# Altium schematic sheet main.SchDoc
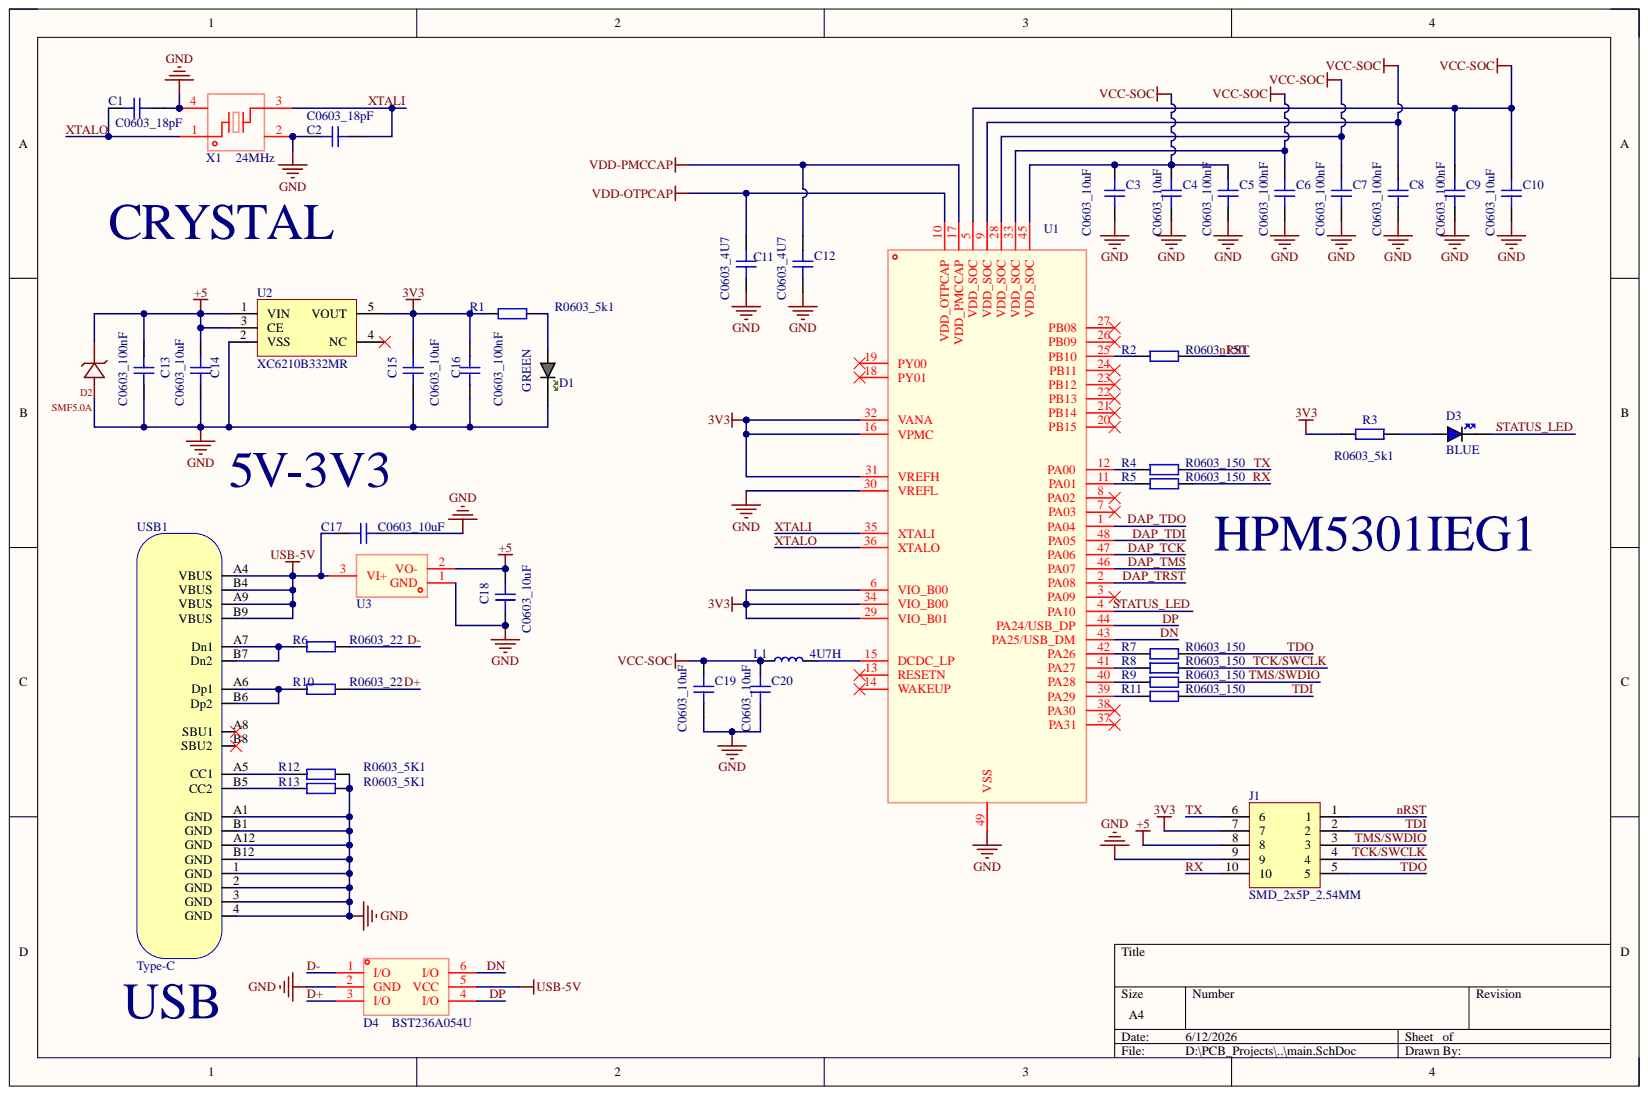
[diagram p0: main.SchDoc]

## Parts
C1  C0603_18pF  [CAP_0603]
C2  C0603_18pF  [CAP_0603]
C3  C0603_10uF  [CAP_0603]
C4  C0603_10uF  [CAP_0603]
C5  C0603_100nF  [CAP_0603]
C6  C0603_100nF  [CAP_0603]
C7  C0603_100nF  [CAP_0603]
C8  C0603_100nF  [CAP_0603]
C9  C0603_100nF  [CAP_0603]
C10  C0603_10uF  [CAP_0603]
C11  C0603_4U7  [CAP_0603]
C12  C0603_4U7  [CAP_0603]
C13  C0603_100nF  [CAP_0603]
C14  C0603_10uF  [CAP_0603]
C15  C0603_10uF  [CAP_0603]
C16  C0603_100nF  [CAP_0603]
C17  C0603_10uF  [CAP_0603]
C18  C0603_10uF  [CAP_0603]
C19  C0603_10uF  [CAP_0603]
C20  C0603_10uF  [CAP_0603]
D1  GREEN  [LED_0805_GREEN]
D2  SMF5.0A  [SOD-123FL_L2.7-W1.8-LS3.8-RD]
D3  BLUE  [LED_0805_BLUE]
D4  BST236A054U  [SOT-23-6_L2.9-W1.6-P0.95-LS2.8-BR]
J1  SMD_2x5P_2.54MM  [SMD_2x5P_2.54MM]
L1  4U7H  [IND_1008]
R1  R0603_5k1  [RES_0603]
R2  R0603_150  [RES_0603]
R3  R0603_5k1  [RES_0603]
R4  R0603_150  [RES_0603]
R5  R0603_150  [RES_0603]
R6  R0603_22  [RES_0603]
R7  R0603_150  [RES_0603]
R8  R0603_150  [RES_0603]
R9  R0603_150  [RES_0603]
R10  R0603_22  [RES_0603]
R11  R0603_150  [RES_0603]
R12  R0603_5K1  [RES_0603]
R13  R0603_5K1  [RES_0603]
U1  HPM5301IEG1  [QFN-48_L6.0-W6.0-P0.40-TL-EP4.2]
U2  XC6210B332MR  [SOT-23-5]
U3  CH213K  [SOT-23-3_L2.9-W1.6-P1.90-LS2.8-BR]  (value not drawn)
USB1  Type-C  [TYPE-C_16P]
X1  24MHz  [CRYSTAL-SMD_4P-L2.5-W2.0-BL-A]

## Nets
3V3: C15.2 C16.2 J1.7 R1.1 R3.1 U1.6(VIO_B00) U1.16(VPMC) U1.29(VIO_B01) U1.31(VREFH) U1.32(VANA) U1.34(VIO_B00) U2.5(VOUT)
+5: C13.1 C14.2 C18.2 D2.1 J1.8 U2.1(VIN) U2.3(CE) U3.2
GND: C1.1 C2.2 C3.1 C4.1 C5.1 C6.1 C7.1 C8.1 C9.1 C10.1 C11.1 C12.1 C13.2 C14.1 C15.1 C16.1 C17.1 C18.1 C19.1 C20.1 D1.K D2.2 D4.2(GND) J1.9 R12.2 R13.2 U1.30(VREFL) U1.49(VSS) U2.2(VSS) U3.1 USB1.1(GND) USB1.2(GND) USB1.3(GND) USB1.4(GND) USB1.A1(GND) USB1.A12(GND) USB1.B1(GND) USB1.B12(GND) X1.2 X1.4
USB-5V: C17.2 D4.5(VCC) U3.3 USB1.A4(VBUS) USB1.A9(VBUS) USB1.B4(VBUS) USB1.B9(VBUS)
VCC-SOC: C3.2 C4.2 C5.2 C6.2 C7.2 C8.2 C9.2 C10.2 C19.2 C20.2 L1.1 U1.5(VDD_SOC) U1.9(VDD_SOC) U1.28(VDD_SOC) U1.33(VDD_SOC) U1.45(VDD_SOC)
VDD-OTPCAP: C11.2 U1.10(VDD_OTPCAP)
VDD-PMCCAP: C12.2 U1.17(VDD_PMCCAP)
D+: D4.3(I/O) R10.2
D-: D4.1(I/O) R6.2
DAP_TCK: U1.47(PA06)
DAP_TDI: U1.48(PA05)
DAP_TDO: U1.1(PA04)
DAP_TMS: U1.46(PA07)
DAP_TRST: U1.2(PA08)
DN: D4.6(I/O) U1.43(PA25/USB_DM)
DP: D4.4(I/O) U1.44(PA24/USB_DP)
nRST: J1.1 R2.2
RX: J1.10 R5.2
STATUS_LED: D3.K U1.4(PA10)
TCK/SWCLK: J1.4 R8.2
TDI: J1.2 R11.2
TDO: J1.5 R7.2
TMS/SWDIO: J1.3 R9.2
TX: J1.6 R4.2
XTALI: C2.1 U1.35(XTALI) X1.3
XTALO: C1.2 U1.36(XTALO) X1.1
NetD1_A: D1.A R1.2
NetD3_A: D3.A R3.2
NetL1_2: L1.2 U1.15(DCDC_LP)
NetR2_1: R2.1 U1.25(PB10)
NetR4_1: R4.1 U1.12(PA00)
NetR5_1: R5.1 U1.11(PA01)
NetR6_1: R6.1 USB1.A7(Dn1) USB1.B7(Dn2)
NetR7_1: R7.1 U1.42(PA26)
NetR8_1: R8.1 U1.41(PA27)
NetR9_1: R9.1 U1.40(PA28)
NetR10_1: R10.1 USB1.A6(Dp1) USB1.B6(Dp2)
NetR11_1: R11.1 U1.39(PA29)
NetR12_1: R12.1 USB1.A5(CC1)
NetR13_1: R13.1 USB1.B5(CC2)

Not connected: U1 3(PA09) 7(PA03) 8(PA02) 13(RESETN) 14(WAKEUP) 18(PY01) 19(PY00) 20(PB15) 21(PB14) 22(PB13) 23(PB12) 24(PB11) 26(PB09) 27(PB08) 37(PA31) 38(PA30); U2 4(NC); USB1 A8(SBU1) B8(SBU2)

## Library data of the parts
C1-C20 = CAP_0603: Capacitor SMD 0603; Component Kind: Standard; Library Name: MyLib.SchLib
D1 = LED_0805_GREEN: LED 0805 GREEN SMD; Component Kind: Standard; Library Name: MyLib.SchLib
D2 = SMF5.0A_C19077497: 极性:单向;反向截止电压(Vrwm):5V;钳位电压:9.2V;峰值脉冲电流(Ipp):21.7A@10/1000us;峰值脉冲功率(Ppp):200W@10/1000us;击穿电压:7V;; Manufacturer: R+O(宏嘉诚); AddInBom: yes; Clamping Voltage: 9.2V; level of protection: IEC 61000-4-2; ModelId: 86f9151e155e4fe2af0c4f2e0a80302e聨0819f05c4eef4c71ace90d822a990e87; ModelName: SOD-123FL_L2.8-W1.8-H1.1-LS3.6; ModelTransform: 141.732,70.866,0,180,0,0,0,0,0; Number of Channels: 单路; Polarity: 单向; Reverse Leakage Current (Ir): 400uA; Reverse Stand-Off Voltage (Vrwm): 5V; Type: TVS; Voltage - Breakdown: 7V; Component Kind: Standard; ConvertToPcb: yes; Datasheet: https://item.szlcsc.com/datasheet/SMF5.0A/20275582.html; Device: SMF5.0A_C19077497; FootprintName: SOD-123FL_L2.7-W1.8-LS3.8-RD; JLCPCB Part Class: Extended Part; Junction Capacitance: -; LCSC Part Name: 单向TVS 5V截止 峰值浪涌电流：21.7A@10/1000us; Library Name: MyLib.SchLib; ManufacturerPart: SMF5.0A; Operating Temperature: -55℃~+150℃; Peak Pulse Current (Ipp): 21.7A@10/1000us; Peak Pulse Power Dissipation (Ppp): 200W@10/1000us; Supplier: LCSC; Supplier Footprint: SOD-123FL; SupplierPart: C19077497; Symbol: SMF5.0A_C19077497
D3 = LED_0805_BLUE: LED 0805 BLUE SMD; Component Kind: Standard; Library Name: MyLib.SchLib
D4 = BST236A054U: 极性:-;反向截止电压(Vrwm):5V;钳位电压:13V;峰值脉冲电流(Ipp):3.5A;峰值脉冲功率(Ppp):45W@8/20us;击穿电压:6V;; Manufacturer: BORN(伯恩半导体); Clamping Voltage: 13V; Junction Capacitance: 0.2pF；0.36pF; level of protection: IEC 61000-4-4；IEC 61000-4-5；IEC 61000-4-2; NPNP_COMPONENT_ID: C406203; Number of Channels: 四路; Peak Pulse Current (Ipp): 3.5A; Reverse Leakage Current (Ir): 500nA; Reverse Stand-Off Voltage (Vrwm): 5V; Type: ESD; Voltage - Breakdown: 6V; Component Kind: Standard; Datasheet: https://item.szlcsc.com/datasheet/BST236A054U/393966.html; JLCPCB Part Class: Extended Part; LCSC Part Name: ESD 5V截止 峰值浪涌电流：3.5A; Library Name: MyLib.SchLib; Manufacturer Part: BST236A054U; Peak Pulse Power Dissipation (Ppp): 45W@8/20us; Supplier: LCSC; Supplier Footprint: SOT-23-6; Supplier Part: C406203
L1 = IND_1008: Inductor SMD 1008; Component Kind: Standard; Library Name: MyLib.SchLib
R1-R13 = RES_0603: Resistor SMD 0603; Component Kind: Standard; Library Name: MyLib.SchLib
U1 = HPM5301IEG1_C49451864: 特性：支持 RV32-IMAFDCBP 指令集。 3.57 Coremark/MHz, 1.98 DMIPS/MHz。 DSP 单元，支持 SIMD 和 DSP 指令。 L1 指令缓存和数据缓存各 16KB。 指令本地存储器 ILM 和数据本地存储器 DLM 各 128KB。 共 288KB 片上 SRAM，包括通用内存和 CPU 的本地存储器; Manufacturer: HPMICRO(先楫半导体); Component Kind: Standard; Datasheet: https://item.szlcsc.com/datasheet/HPM5301IEG1/51998746.html; JLCPCB Part Class: Extended Part; LCSC Part Name: 基于RISC-V内核的32位高性能微控制器; Library Name: MyLib.SchLib; Manufacturer Part: HPM5301IEG1; NPNP_COMPONENT_ID: C49451864; Supplier: LCSC; Supplier Footprint: QFN-48(6x6); Supplier Part: C49451864
U2 = XC6210B332MR: LDO 3V3@700mA; Component Kind: Standard; Library Name: MyLib.SchLib
U3 = CH213K: Manufacturer: WCH(南京沁恒); NPNP_COMPONENT_ID: C5456874; Component Kind: Standard; Datasheet: https://item.szlcsc.com/datasheet/CH213K/6369803.html; Description: CH213K; JLCPCB Part Class: Extended Part; LCSC Part Name: CH213K; Library Name: CHIPS.SchLib; Manufacturer Part: CH213K; Supplier: LCSC; Supplier Footprint: SOT-23-3; Supplier Part: C5456874
USB1 = USB-Type-C: USB-Type-C_16P; Component Kind: Standard; Library Name: MyLib.SchLib
X1 = XTAL_2520_24MHz: 晶振类型:贴片晶振;频率:24MHz;常温频差:±10ppm;负载电容:18pF;频率稳定度:±10ppm;; Manufacturer: HCI(杭晶); Manufacturer Part: XC22M4-32.000-F08NNHP; Equivalent Series Resistance(ESR): 60Ω; Frequency Stability: ±10ppm; Load Capacitance: 8pF; NPNP_COMPONENT_ID: C2842280; Value: 32MHz; Component Kind: Standard; Datasheet: https://item.szlcsc.com/datasheet/XC22M4-32.000-F08NNHP/3026554.html; JLCPCB Part Class: Extended Part; LCSC Part Name: 2520 4P 32MHz 8pF ±10ppm -20~+70℃ (±10ppm); Library Name: MyLib.SchLib; Normal temperature Frequency Tolerance: ±10ppm; Operating Temperature: -20℃~+70℃; Supplier: LCSC; Supplier Footprint: SMD2520-4P; Supplier Part: C2842280; Type: 贴片晶振
J1 = SMD_2x5P_2.54MM: Component Kind: Standard; Description: SMD_2x5P_2.54MM; Library Name: MyLib.SchLib
